# Altium schematic sheet CPU - HDMI, CSI, DSI, LVDS.SchDoc
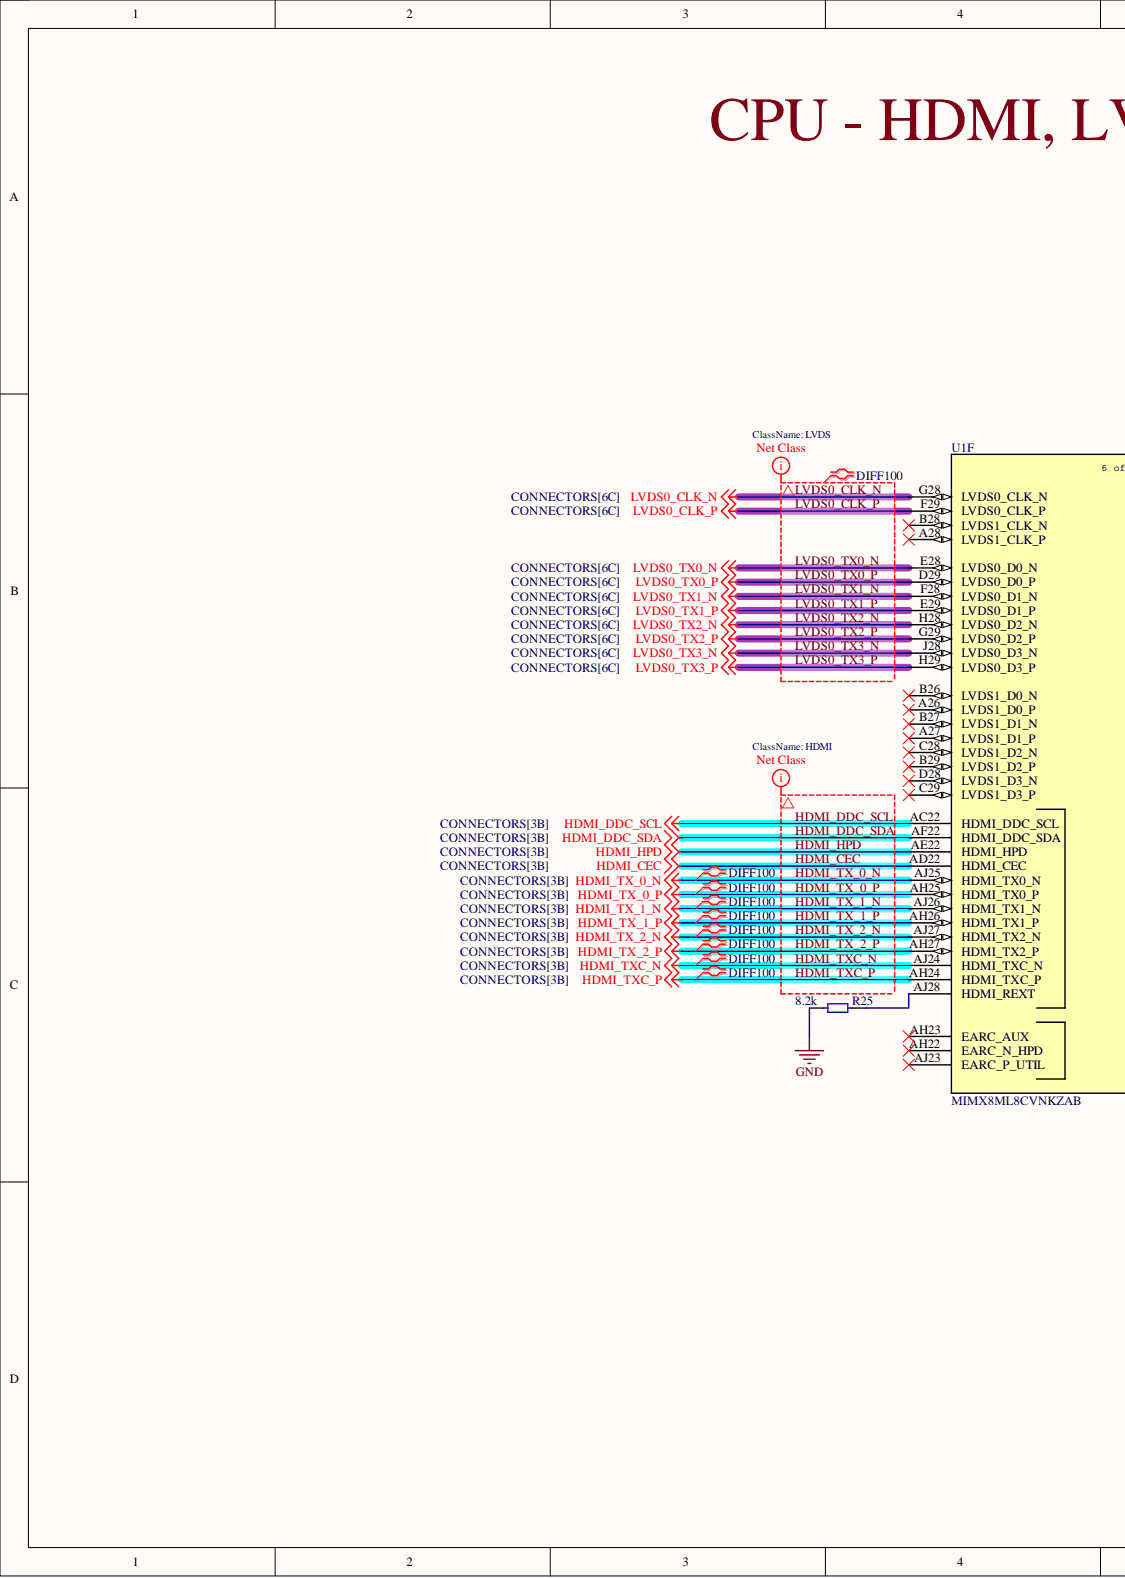
[diagram p0: CPU - HDMI, CSI, DSI, LVDS.SchDoc, left]
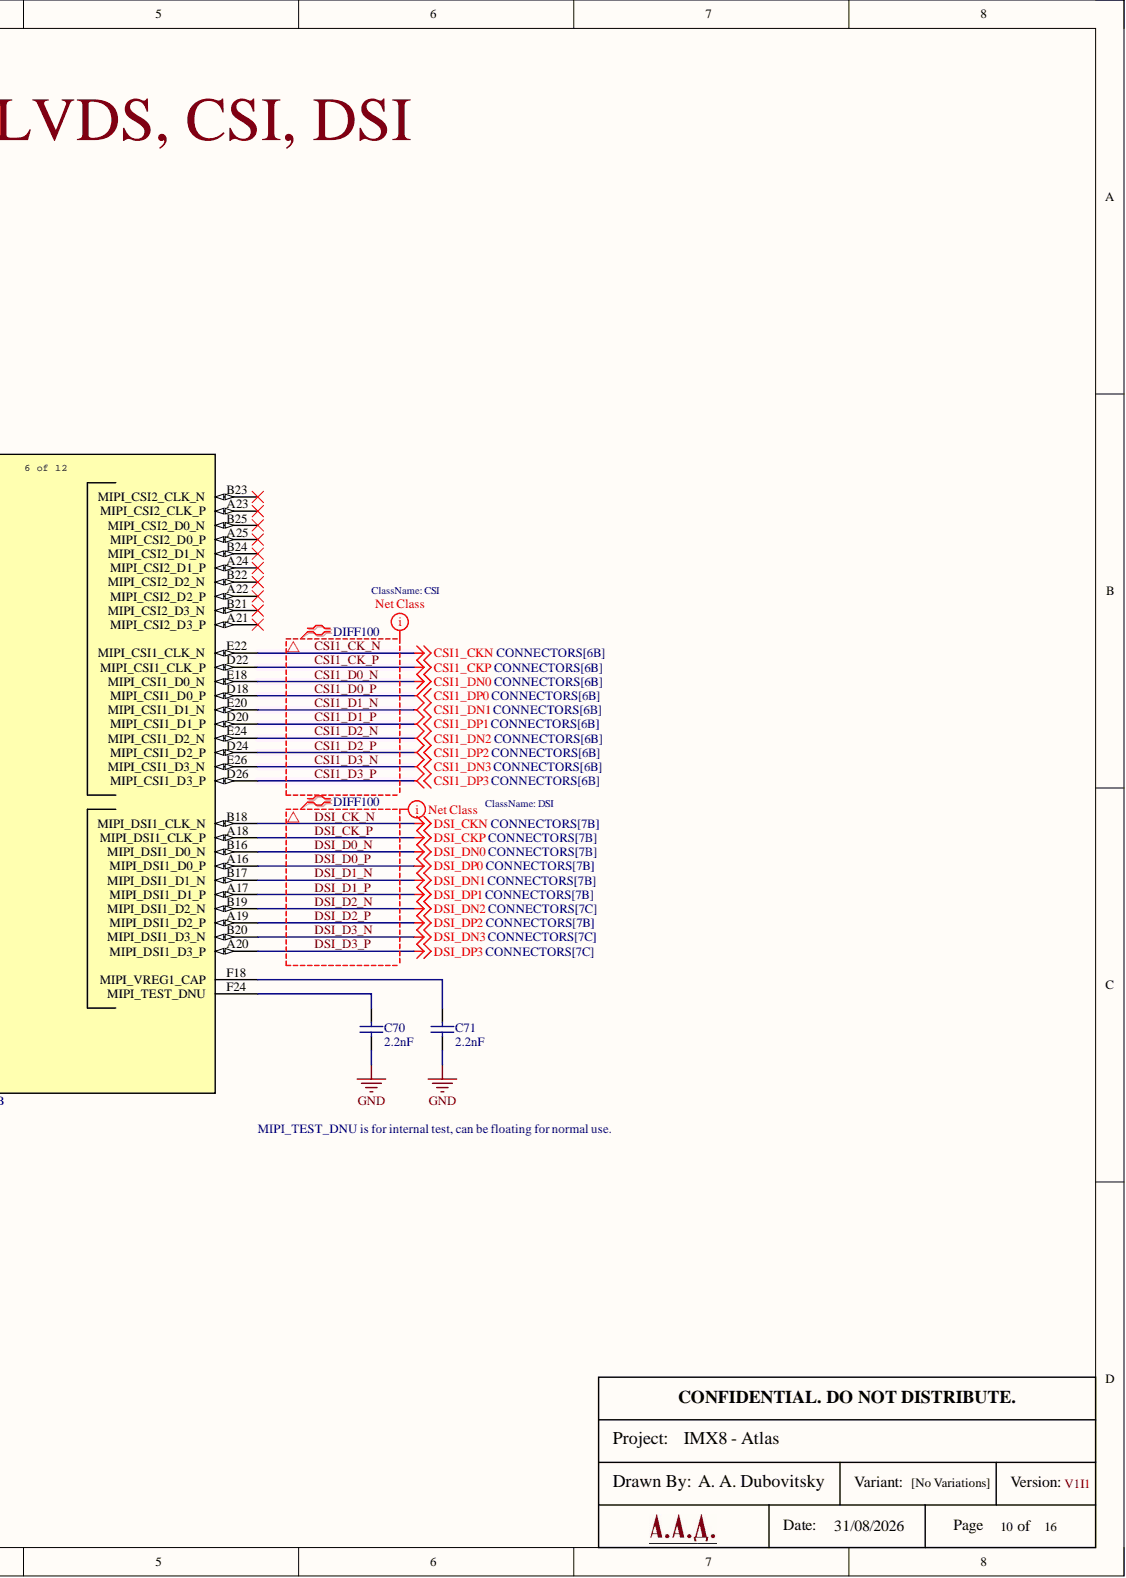
[diagram p1: CPU - HDMI, CSI, DSI, LVDS.SchDoc, right]

## Parts
C70  2.2nF  [CAP 0201_0603 MINI]
C71  2.2nF  [CAP 0201_0603 MINI]
R25  8.2k  [RES 0402_1005]
U1  MIMX8ML8CVNKZAB  [BGA548C50P29X29_1500X1500X148]  (part F)

## Nets
GND: C70.1 C71.1 R25.2
CSI1_CK_N: U1.E22(MIPI_CSI1_CLK_N)
CSI1_CK_P: U1.D22(MIPI_CSI1_CLK_P)
CSI1_D0_N: U1.E18(MIPI_CSI1_D0_N)
CSI1_D0_P: U1.D18(MIPI_CSI1_D0_P)
CSI1_D1_N: U1.E20(MIPI_CSI1_D1_N)
CSI1_D1_P: U1.D20(MIPI_CSI1_D1_P)
CSI1_D2_N: U1.E24(MIPI_CSI1_D2_N)
CSI1_D2_P: U1.D24(MIPI_CSI1_D2_P)
CSI1_D3_N: U1.E26(MIPI_CSI1_D3_N)
CSI1_D3_P: U1.D26(MIPI_CSI1_D3_P)
DSI_CK_N: U1.B18(MIPI_DSI1_CLK_N)
DSI_CK_P: U1.A18(MIPI_DSI1_CLK_P)
DSI_D0_N: U1.B16(MIPI_DSI1_D0_N)
DSI_D0_P: U1.A16(MIPI_DSI1_D0_P)
DSI_D1_N: U1.B17(MIPI_DSI1_D1_N)
DSI_D1_P: U1.A17(MIPI_DSI1_D1_P)
DSI_D2_N: U1.B19(MIPI_DSI1_D2_N)
DSI_D2_P: U1.A19(MIPI_DSI1_D2_P)
DSI_D3_N: U1.B20(MIPI_DSI1_D3_N)
DSI_D3_P: U1.A20(MIPI_DSI1_D3_P)
HDMI_CEC: U1.AD22(HDMI_CEC)
HDMI_DDC_SCL: U1.AC22(HDMI_DDC_SCL)
HDMI_DDC_SDA: U1.AF22(HDMI_DDC_SDA)
HDMI_HPD: U1.AE22(HDMI_HPD)
HDMI_TX_0_N: U1.AJ25(HDMI_TX0_N)
HDMI_TX_0_P: U1.AH25(HDMI_TX0_P)
HDMI_TX_1_N: U1.AJ26(HDMI_TX1_N)
HDMI_TX_1_P: U1.AH26(HDMI_TX1_P)
HDMI_TX_2_N: U1.AJ27(HDMI_TX2_N)
HDMI_TX_2_P: U1.AH27(HDMI_TX2_P)
HDMI_TXC_N: U1.AJ24(HDMI_TXC_N)
HDMI_TXC_P: U1.AH24(HDMI_TXC_P)
LVDS0_CLK_N: U1.G28(LVDS0_CLK_N)
LVDS0_CLK_P: U1.F29(LVDS0_CLK_P)
LVDS0_TX0_N: U1.E28(LVDS0_D0_N)
LVDS0_TX0_P: U1.D29(LVDS0_D0_P)
LVDS0_TX1_N: U1.F28(LVDS0_D1_N)
LVDS0_TX1_P: U1.E29(LVDS0_D1_P)
LVDS0_TX2_N: U1.H28(LVDS0_D2_N)
LVDS0_TX2_P: U1.G29(LVDS0_D2_P)
LVDS0_TX3_N: U1.J28(LVDS0_D3_N)
LVDS0_TX3_P: U1.H29(LVDS0_D3_P)
NetC70_2: C70.2 U1.F24(MIPI_TEST_DNU)
NetC71_2: C71.2 U1.F18(MIPI_VREG1_CAP)
NetR25_1: R25.1 U1.AJ28(HDMI_REXT)

Not connected: U1 A21(MIPI_CSI2_D3_P) A22(MIPI_CSI2_D2_P) A23(MIPI_CSI2_CLK_P) A24(MIPI_CSI2_D1_P) A25(MIPI_CSI2_D0_P) A26(LVDS1_D0_P) A27(LVDS1_D1_P) A28(LVDS1_CLK_P) AH22(EARC_N_HPD) AH23(EARC_AUX) AJ23(EARC_P_UTIL) B21(MIPI_CSI2_D3_N) B22(MIPI_CSI2_D2_N) B23(MIPI_CSI2_CLK_N) B24(MIPI_CSI2_D1_N) B25(MIPI_CSI2_D0_N) B26(LVDS1_D0_N) B27(LVDS1_D1_N) B28(LVDS1_CLK_N) B29(LVDS1_D2_P) C28(LVDS1_D2_N) C29(LVDS1_D3_P) D28(LVDS1_D3_N)

## Library data of the parts
C70 C71 = C161488: Cap. 2.2nF 25V ±10% 0201; Component Kind: Standard; Library Name: Atlas - Symbols.SchLib
R25 = C2909385: Res. 8.2kΩ 62.5mW 50V ±1% 0402; Component Kind: Standard; Library Name: Atlas - Symbols.SchLib
U1 = MIMX8ML8CVNKZAB: IC ARM MCU; Manufacturer_Name: NXP; Component Kind: Standard; Manufacturer_Part_Number: MIMX8ML8CVNKZAB; RefDes: RefDes; Type: Type; Copyright: Copyright (C) 2026 Ultra Librarian. All rights reserved.; Library Name: Atlas - Symbols.SchLib
